# Altium schematic sheet power supply.SchDoc
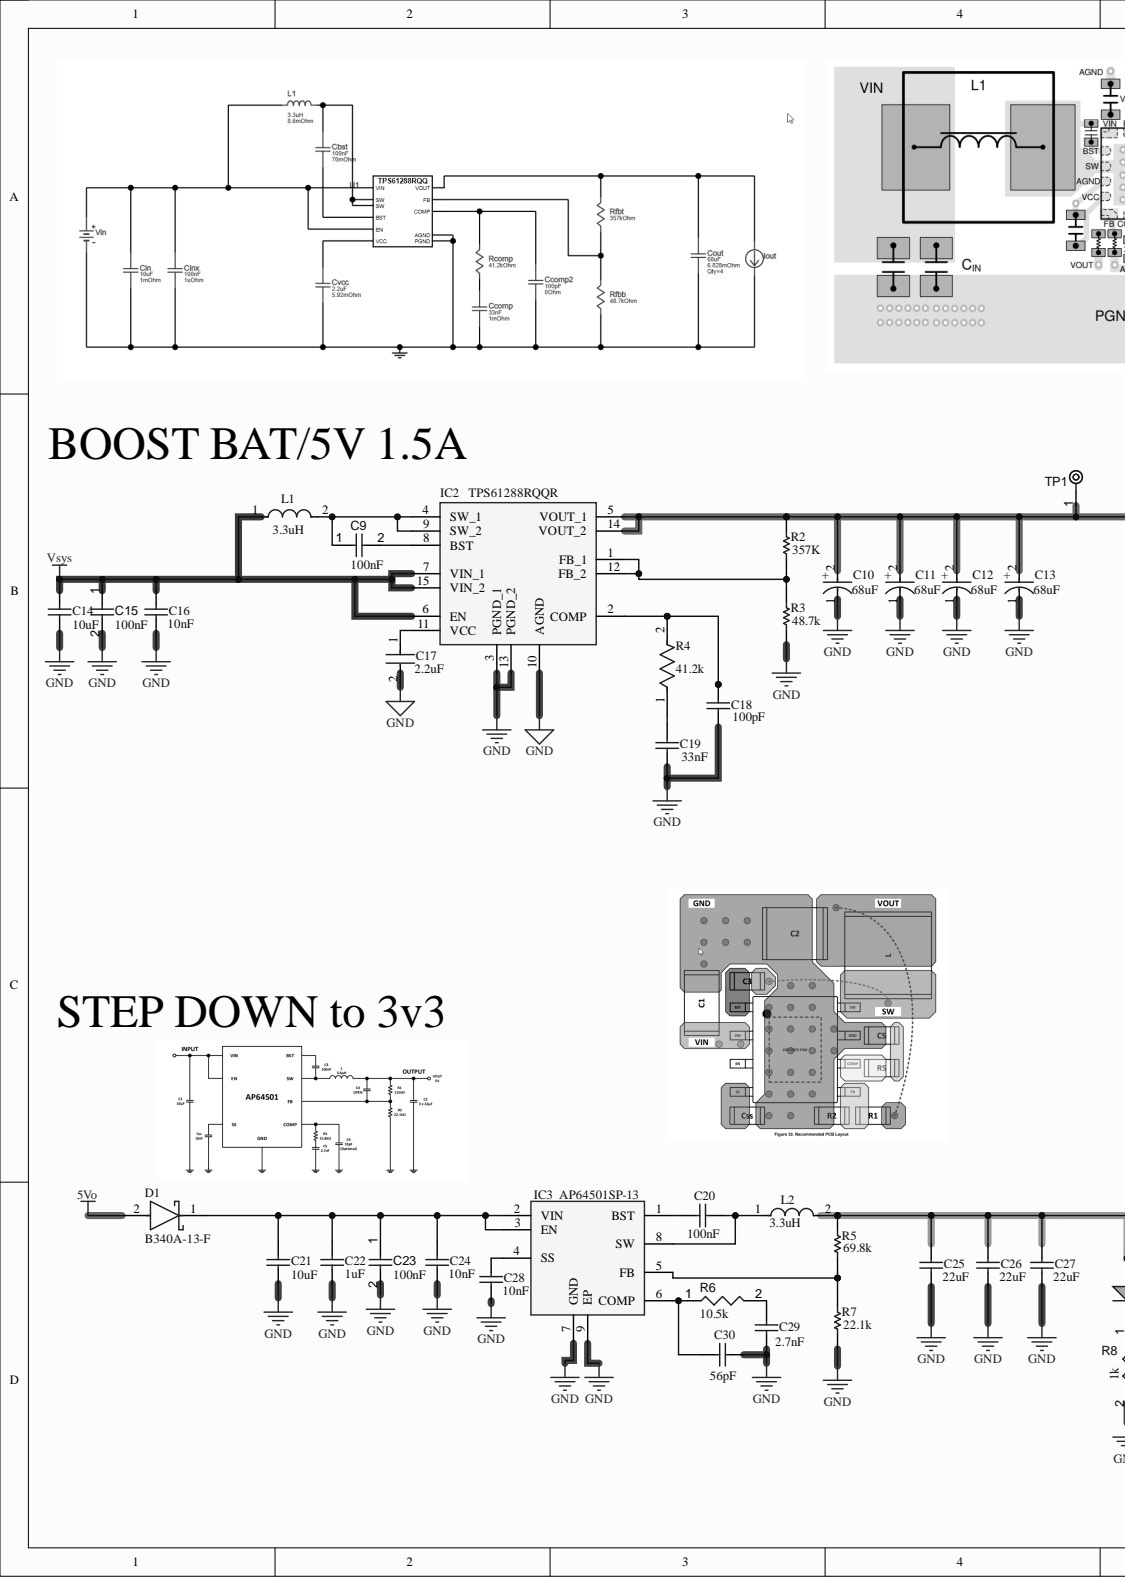
[diagram p0: power supply.SchDoc, left]
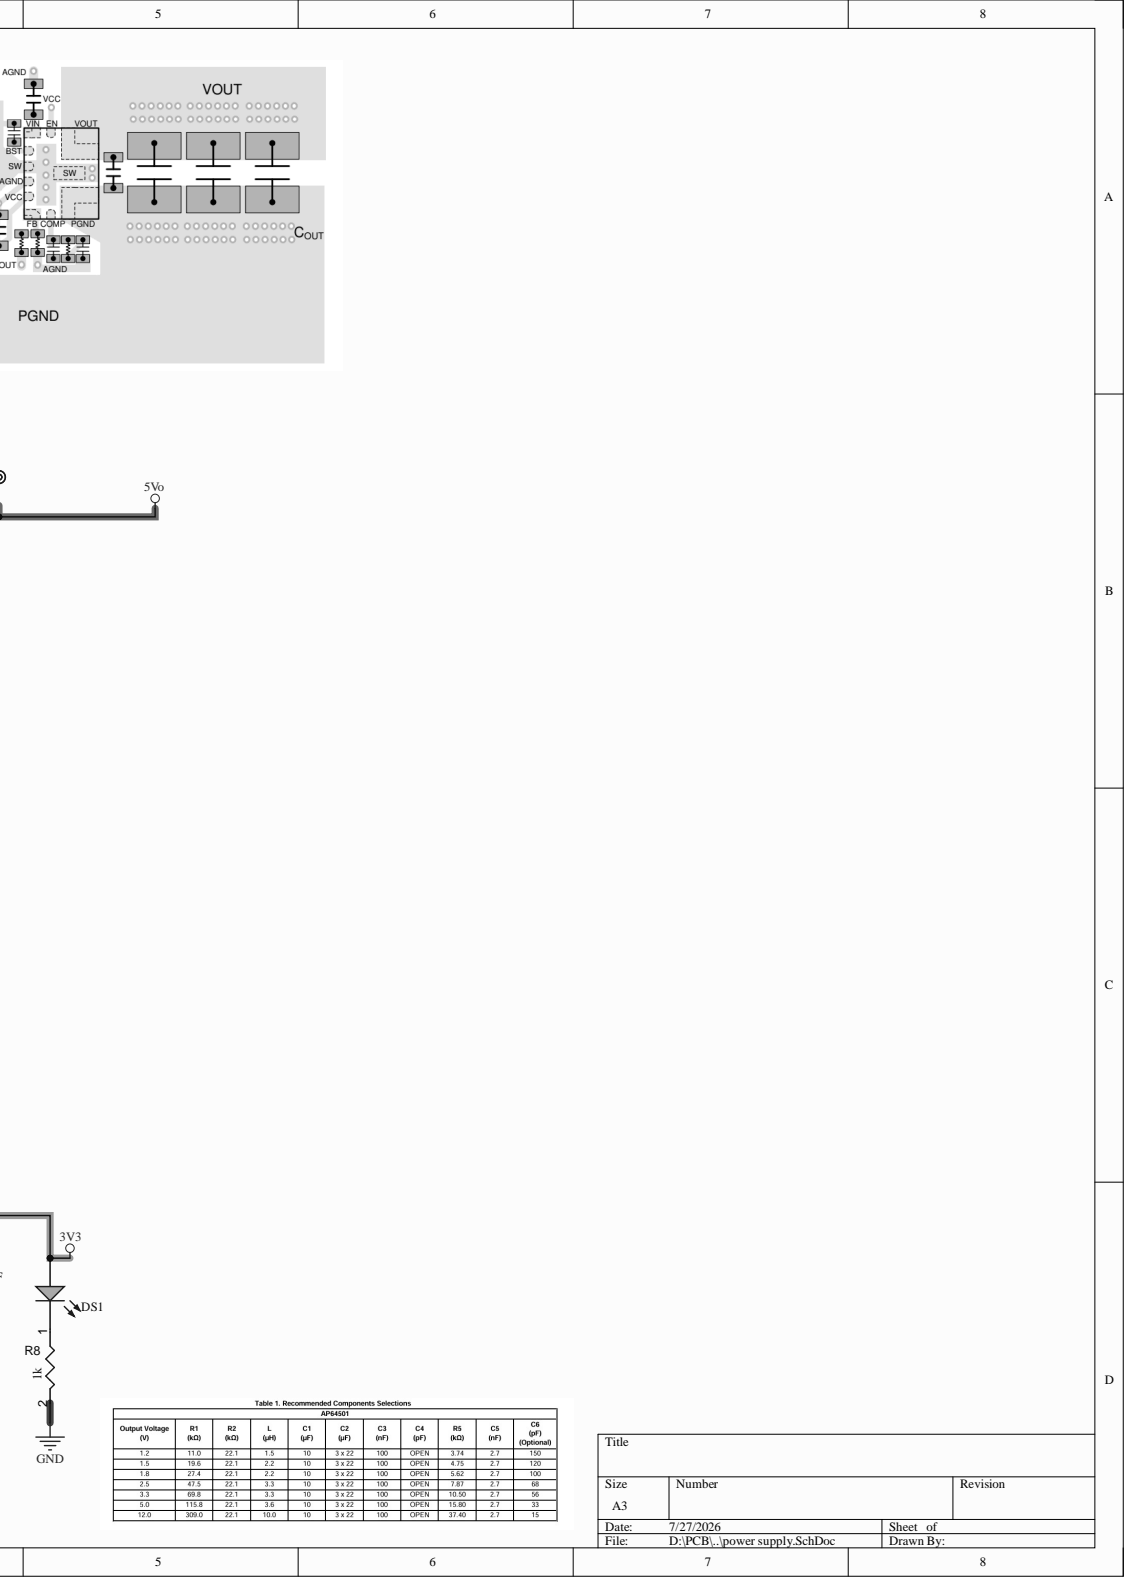
[diagram p1: power supply.SchDoc, right]

## Parts
C9  value 100nF  [CAPC1005X56X25ML10T15]  (hidden comment: Capacitor 0.1 uF +/- 5% 16 V 0402)
C10  Capacitance: 68uF  [FP-EEH-D8-MFG]  (hidden comment: EEHZA1V680XP)
C11  Capacitance: 68uF  [FP-EEH-D8-MFG]  (hidden comment: EEHZA1V680XP)
C12  Capacitance: 68uF  [FP-EEH-D8-MFG]  (hidden comment: EEHZA1V680XP)
C13  Capacitance: 68uF  [FP-EEH-D8-MFG]  (hidden comment: EEHZA1V680XP)
C14  value 10uF  [CAPC0603(1608)100_M]  (hidden comment: CAP 10uF 6.3V 0603(1608))
C15  value 100nF  [CAPC1005X56X25ML10T15]  (hidden comment: Capacitor 0.1 uF +/- 5% 16 V 0402)
C16  value 10nF  [CAPC0603X33X15ML03T05]  (hidden comment: 0201ZC103JAT2A)
C17  Capacitance: 2.2uF  [FP-GRM188-0_1-e0_2_0_5-IPC_A]  (hidden comment: GRM188R71A225KE15D)
C18  value 100pF  [CAPC1005X55X25ML05T05]  (hidden comment: VJ0402A101JXJCW1BC)
C19  value 33nF  [CAPC1005X56X25ML10T15]  (hidden comment: 0402ZD333KAT2A)
C20  value 100nF  [CAPC1005X55X25ML05T05]  (hidden comment: VJ0402G104KXQPW1BC)
C21  value 10uF  [CAPC0603(1608)100_M]  (hidden comment: CAP 10uF 6.3V 0603(1608))
C22  value 1uF  [CAPC1608X90X35ML15T15]  (hidden comment: 0603YD105KAT4A)
C23  value 100nF  [CAPC1005X56X25ML10T15]  (hidden comment: Capacitor 0.1 uF +/- 5% 16 V 0402)
C24  value 10nF  [CAPC0603X33X15ML03T05]  (hidden comment: 0201ZC103JAT2A)
C25  value 22uF  [CAPC1608X90X35ML15T15]  (hidden comment: 06034D226MAT2A)
C26  value 22uF  [CAPC1608X90X35ML15T15]  (hidden comment: 06034D226MAT2A)
C27  value 22uF  [CAPC1608X90X35ML15T15]  (hidden comment: 06034D226MAT2A)
C28  value 10nF  [CAPC0603X33X15ML03T05]  (hidden comment: 0201ZC103JAT2A)
C29  value 2.7nF  [CAPC1005X56X25ML10T15]  (hidden comment: 0402YC272JAT2A)
C30  value 56pF  [CAPC1005X56X25ML10T15]  (hidden comment: 04023A560KAT2A)
D1  B340A-13-F  [FP-SMA-MFG]
DS1  LTST-C190KFKT  [LTST-C190KFKT_V]  (value not drawn)
IC2  TPS61288RQQR  [TPS61288RQQR]
IC3  AP64501SP-13  [SOIC127P600X163-9N]
L1  Inductance: 3.3uH  [FP-MPX1D1250-MFG]  (hidden comment: MPX1D1250L3R3)
L2  Inductance: 3.3uH  [FP-MPX1D1250-MFG]  (hidden comment: MPX1D1250L3R3)
R2  value 357K  [RESC1608X55X25ML10T15]  (hidden comment: RC0603FR-07357KL)
R3  value 48.7k  [RESC1608X55X25ML10T15]  (hidden comment: RC0603FR-07487KL)
R4  Resistance: 41.2k  [FP-RMCF0603-IPC_A]  (hidden comment: RMCF0603FG412K)
R5  value 69.8k  [RESC1608X55X25ML10T15]  (hidden comment: RC0603FR-07698KL)
R6  value 10.5k  [RESC1608X55X25ML10T15]  (hidden comment: Resistor 10k +/-1% 0603 100 mW)
R7  value 22.1k  [RESC1608X55X25ML10T15]  (hidden comment: RC0603FR-07698KL)
R8  value 1k  [RESC1608X55X25ML10T15]  (hidden comment: Resistor 10k +/-1% 0603 100 mW)
TP1  TPAD6R  [TP06R]  (value not drawn)  (no BOM)

## Nets
3V3: C25.2 C26.2 C27.2 DS1.1 L2.2 R5.2
5Vo: C10.2 C11.2 C12.2 C13.2 D1.2 IC2.5(VOUT_1) IC2.14(VOUT_2) R2.2 TP1.1
GND: C10.1 C11.1 C12.1 C13.1 C14.1 C15.2 C16.1 C17.2 C18.1 C19.1 C21.1 C22.2 C23.2 C24.1 C25.1 C26.1 C27.1 C28.1 C29.1 C30.2 IC2.3(PGND_1) IC2.10(AGND) IC2.13(PGND_2) IC3.7(GND) IC3.9(EP) R3.1 R7.1 R8.2
Vsys: C14.2 C15.1 C16.2 IC2.6(EN) IC2.7(VIN_1) IC2.15(VIN_2) L1.1
NetC9_1: C9.1 IC2.4(SW_1) IC2.9(SW_2) L1.2
NetC9_2: C9.2 IC2.8(BST)
NetC17_1: C17.1 IC2.11(VCC)
NetC18_2: C18.2 IC2.2(COMP) R4.2
NetC19_2: C19.2 R4.1
NetC20_1: C20.1 IC3.1(BST)
NetC20_2: C20.2 IC3.8(SW) L2.1
NetC21_2: C21.2 C22.1 C23.1 C24.2 D1.1 IC3.2(VIN) IC3.3(EN)
NetC28_2: C28.2 IC3.4(SS)
NetC29_2: C29.2 R6.2
NetC30_1: C30.1 IC3.6(COMP) R6.1
NetDS1_2: DS1.2 R8.1
NetIC2_1: IC2.1(FB_1) IC2.12(FB_2) R2.1 R3.2
NetIC3_5: IC3.5(FB) R5.1 R7.2

## Library data of the parts
C9 C15 C23 = CMP-001-00001-6: Chip Capacitor, 0.1 uF, +/- 5%, 16 V, 0402 (1005 Metric); Capacitor Type: Ceramic; Voltage Rating: 16V; Case/Package: 0402; Component Kind: Standard; Max Operating Temperature: 125°C; Min Operating Temperature: -55°C; Mounting Technology: SM; Pins: 2; RoHS Compliant: True; Tolerance: 5%; Library Name: Carlos Christopher Carbajal Jordán
C10-C13 = CMP-05428-001211-1: Conductive Polymer Hybrid Aluminum Electrolytic Capacitors 68uF ±20% 35V RADIAL SMT 6.3x7.7mm; ESR (Equivalent Series Resistance): 35mR; Lead Diameter: 0.65mm; Leakage Current: 23.8uA; Life (Hours): 5000Hour; Polarity: Polar; Ripple Current: 2A; Case/Package: Radial; Component Kind: Standard; Construction: Cylindrical; Diameter: 6.3mm; Height: 7.7mm; Height - Seated (Max): 0.315inch; Lead Free: Lead Free; Lead Pitch: 1.8mm; Length: 7.7mm; Library Name: Altium Content Vault; Material: Polymer; Max Operating Temperature: 105°C; Min Operating Temperature: -55°C; Mount: Surface Mount; Number of Pins: 8; Package Quantity: 900; Packaging: Tape and Reel; Radiation Hardening: No; Ratings: AEC-Q200; REACH SVHC: No SVHC; Ripple Current (AC): 2A; Series: ZA; Technology: HYBRID; Termination: SMD/SMT; Tolerance: 20%; Voltage: 35V; Voltage Rating: 35V; Voltage Rating (DC): 35V
C14 C21 = CMP-1035-02796-1: CAP 10uF 6.3V ±10% 0603 (1608 Metric) Thickness 1mm SMD; Case-EIA: 0603; Case-Metric: 1608; Tolerance: Â±10%; Component Kind: Standard; Library Name: Altium Content Vault; Max Thickness: 1 mm; Rated Voltage: 6.3 V; Technology: SMT
C16 C24 C28 = CMP-2010-00529-1: Manufacturer: AVX Interconnect / Elco; Component Kind: Standard; Library Name: Altium Content Vault; Part Number: 0201ZC103JAT2A
C17 = CMP-2006-02836-2: Chip Multilayer Ceramic Capacitors for General Purpose, 0603, 2.2uF, X7R, 15%, 10%, 10V; Case Code (Imperial): 0603; Case Code (Metric): 1608; Case/Package: 0603; Component Kind: Standard; Depth: 0.8mm; Dielectric: X7R; Height: 0.8mm; Lead Free: Lead Free; Length: 1.6mm; Library Name: Altium Content Vault; Material: Ceramic; Max Operating Temperature: 125°C; Min Operating Temperature: -55°C; Mount: Surface Mount; Number of Pins: 2; Package Quantity: 1; Packaging: Cut Tape; REACH SVHC: No SVHC; Termination: SMD/SMT; Thickness: 0.035inch; Tolerance: 10%; Voltage: 10V; Voltage Rating: 10V; Voltage Rating (DC): 10V; Weight: 7.1E-05oz; Width: 0.031inch
C18 = CMP-2008-01280-1: Manufacturer: Vitramon; Component Kind: Standard; Library Name: Altium Content Vault; Part Number: VJ0402A101JXJCW1BC
C19 = CMP-2008-02891-1: Manufacturer: AVX Interconnect / Elco; Component Kind: Standard; Library Name: Altium Content Vault; Part Number: 0402ZD333KAT2A
C20 = CMP-2008-01360-1: Manufacturer: Vitramon; Component Kind: Standard; Library Name: Altium Content Vault; Part Number: VJ0402G104KXQPW1BC
C22 = CMP-2006-04533-1: Manufacturer: AVX Interconnect / Elco; Component Kind: Standard; Library Name: Altium Content Vault; Part Number: 0603YD105KAT4A
C25-C27 = CMP-2006-04205-1: Manufacturer: AVX Interconnect / Elco; Component Kind: Standard; Library Name: Altium Content Vault; Part Number: 06034D226MAT2A
C29 = CMP-2008-02966-1: Manufacturer: AVX Interconnect / Elco; Component Kind: Standard; Library Name: Altium Content Vault; Part Number: 0402YC272JAT2A
C30 = CMP-2008-02504-1: Manufacturer: AVX Interconnect / Elco; Component Kind: Standard; Library Name: Altium Content Vault; Part Number: 04023A560KAT2A
D1 = CMP-1667-00003-3: DIODE SCHOTTKY 40V 3A SMA; Capacitance: 250pF; Element Configuration: Single; Forward Voltage: 500mV; Max Forward Surge Current (Ifsm): 80A; Max Reverse Current: 500uA; Polarity: Standard; Average Rectified Current: 3A; Case/Package: SMA; Component Kind: Standard; Contact Plating: Tin; Current: 3A; Current Rating: 3A; Forward Current: 3A; Height: 2.3mm; Lead Free: Lead Free; Length: 4.6mm; Library Name: Altium Content Vault; Max Operating Temperature: 125°C; Max Repetitive Reverse Voltage (Vrrm): 40V; Max Reverse Voltage (DC): 40V; Min Operating Temperature: -55°C; Mount: Surface Mount; Number of Pins: 2; Output Current: 3A; Package Quantity: 5000; Packaging: Tape and Reel; Peak Non-Repetitive Surge Current: 80A; Radiation Hardening: No; REACH SVHC: No SVHC; RoHS Compliant: Yes; Series: -; Termination: SMD/SMT; Voltage: 40V; Voltage Rating (DC): 40V; Weight: 0.002258oz; Width: 2.92mm
DS1 = CMP-007-00023-2: LED Uni-Color Orange, 75 mW, 30 mA, -35 to 85 degC, 2-Pin SMD, RoHS, Tape and Reel; Manufacturer: Lite-On; Forward Current: 20mA; Forward Voltage: 2V; Lens Style: Diffused; Luminous Intensity: 90mcd; Power: 75mW; Viewing Angle: 130degrees; Case/Package: 0603; Colour: Orange; Component Kind: Standard; Datasheet URL: http://optoelectronics.liteon.com/upload/download/DS-22-99-0186/S_110_LTST-C190KFKT.pdf; Datasheet Version: Rev. I, 09/2011; Illumination Colour: Orange; Manufacturer URL: http://www.us.liteon.com/; Max Operating Temperature: 85°C; Min Operating Temperature: -55°C; Mounting Technology: SM; Nominal Vf: 2V; Package Description: 2-Pin Surface Mount Device, Body 1.6 x 0.8 mm; Package Quantity: 3000; Package Reference: C190KFKT; Packaging: TapeandReel; Pins: 2; RoHS Compliant: True; Library Name: Carlos Christopher Carbajal Jordán
IC2 = TPS61288RQQR: Integrated Circuit; Manufacturer_Name: Texas Instruments; Arrow Part Number: TPS61288RQQR; Arrow Price/Stock: https://www.arrow.com/en/products/tps61288rqqr/texas-instruments?utm_currency=USD&region=europe; Component Kind: Standard; Datasheet Link: https://www.ti.com/lit/ds/symlink/tps61288.pdf?ts=1609351344517&ref_url=https%253A%252F%252Fwww.ti.com%252Fpower-management%252Fnon-isolated-dc-dc-switching-regulators%252Fstep-up-boost%252Fboost-converters-integrated-switch%252Fproducts.html; Height: 1mm; Library Name: TPS61288RQQR.SchLib; Manufacturer_Part_Number: TPS61288RQQR; Mouser Part Number: 595-TPS61288RQQR; Mouser Price/Stock: https://www.mouser.co.uk/ProductDetail/Texas-Instruments/TPS61288RQQR?qs=eP2BKZSCXI7ZX8saYJkaIw%3D%3D
IC3 = AP64501SP-13: Integrated Circuit; Manufacturer_Name: Diodes Incorporated; Arrow Part Number: AP64501SP-13; Arrow Price/Stock: https://www.arrow.com/en/products/ap64501sp-13/diodes-incorporated?utm_currency=USD&region=nac; Component Kind: Standard; Datasheet Link: https://www.diodes.com//assets/Datasheets/AP64501.pdf; Height: 1.63mm; Library Name: AP64501.SchLib; Manufacturer_Part_Number: AP64501SP-13; Mouser Part Number: 621-AP64501SP-13; Mouser Price/Stock: https://www.mouser.co.uk/ProductDetail/Diodes-Incorporated/AP64501SP-13?qs=P1JMDcb91o7FS0GU%252BW7TIQ%3D%3D
L1 L2 = CMP-03027-000009-1: FIXED IND 3.3UH 16.8A 7.4MOHM SM; Core Material: Metal; DC Resistance (DCR): 7.4mR; Saturation Current: 22A; Self Resonant Frequency: 17MHz; Shielding: Shielded; Test Frequency: 100kHz; Tolerance: 20%; Case/Package: Nonstandard; Component Kind: Standard; Library Name: Altium Content Vault; Max Operating Temperature: 155°C; Min Operating Temperature: -55°C; Mount: Surface Mount; RoHS Compliant: Yes; Series: METCOM MPX
R2 = CMP-2000-04022-1: Manufacturer: Yageo; Component Kind: Standard; Library Name: Altium Content Vault; Part Number: RC0603FR-07357KL
R3 = CMP-2000-04060-1: Manufacturer: Yageo; Component Kind: Standard; Library Name: Altium Content Vault; Part Number: RC0603FR-07487KL
R4 = CMP-26527-004815-1: 412kΩ ±1% 0.1W 0603 Thick Film Chip Resistor AEC-Q200 compliant; Temperature Coefficient: 100ppm/°C; Case/Package: 0603; Component Kind: Standard; Contact Plating: Tin; Library Name: Altium Content Vault; Max Operating Temperature: 155°C; Min Operating Temperature: -55°C; Mount: Surface Mount; Packaging: Tape and Reel; Radiation Hardening: No; Resistor Type: Thick Film; RoHS Compliant: Yes; Tolerance: 1%
R5 = CMP-2000-04113-1: Manufacturer: Yageo; Part Number: C3016381; Component Kind: Standard; Library Name: Altium Content Vault
R6 R8 = CMP-009-00017-5: Chip Resistor, 10 KOhm, +/- 1%, 01 W, -55 to 155 degC, 0603 (1608 Metric); Power: 100mW; Voltage Rating: 50V; Case/Package: 0603; Component Kind: Standard; Max Operating Temperature: 155°C; Min Operating Temperature: -55°C; Mounting Technology: SM; Pins: 2; RoHS Compliant: True; Tolerance: 1%; Library Name: Carlos Christopher Carbajal Jordán
R7 = CMP-2000-04113-1: Manufacturer: Yageo; Part Number: C2960862; Component Kind: Standard; Library Name: Altium Content Vault
TP1 = CMP-00028-00002-2: 0.6mm round; Pins: 1; Library Name: Carlos Christopher Carbajal Jordán
